# Altium schematic sheet LCD.SchDoc
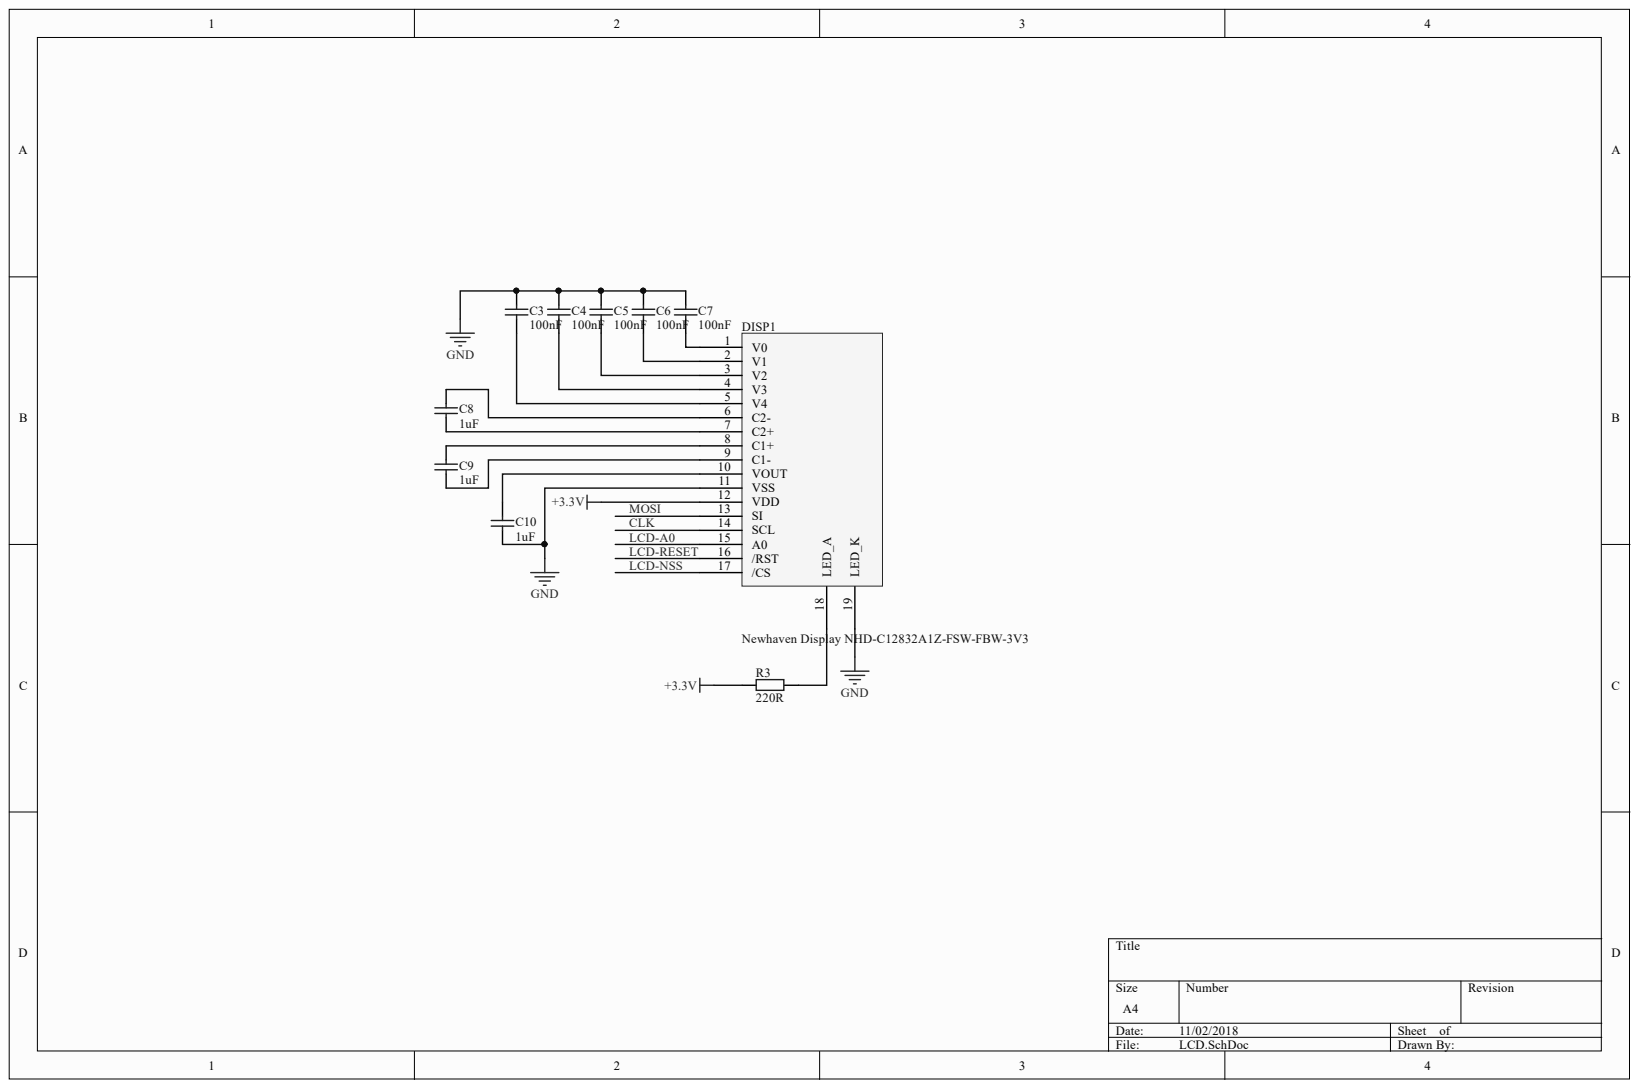
[diagram p0: LCD.SchDoc]

## Parts
C3  100nF  [PCB-14j6yrx10pagyq47cjuv-1]
C4  100nF  [PCB-14j6yrx10pagyq47cjuv-1]
C5  100nF  [PCB-14j6yrx10pagyq47cjuv-1]
C6  100nF  [PCB-14j6yrx10pagyq47cjuv-1]
C7  100nF  [PCB-14j6yrx10pagyq47cjuv-1]
C8  1uF  [CAPC1608X90X35NL15T15]
C9  1uF  [CAPC1608X90X35NL15T15]
C10  1uF  [CAPC1608X90X35NL15T15]
DISP1  Newhaven Display NHD-C12832A1Z-FSW-FBW-3V3  [Metric_Newhaven Display]
R3  220R  [PCB-jh6ykb2j6l1shybbw4je-1]

## Nets
+3.3V: DISP1.12(VDD) R3.1
GND: C3.2 C4.2 C5.2 C6.2 C7.2 C10.1 DISP1.11(VSS) DISP1.19(LED_K)
CLK: DISP1.14(SCL)
LCD-A0: DISP1.15(A0)
LCD-NSS: DISP1.17(/CS)
LCD-RESET: DISP1.16(/RST)
MOSI: DISP1.13(SI)
NetC3_1: C3.1 DISP1.5(V4)
NetC4_1: C4.1 DISP1.4(V3)
NetC5_1: C5.1 DISP1.3(V2)
NetC6_1: C6.1 DISP1.2(V1)
NetC7_1: C7.1 DISP1.1(V0)
NetC8_1: C8.1 DISP1.7(C2+)
NetC8_2: C8.2 DISP1.6(C2-)
NetC9_1: C9.1 DISP1.9(C1-)
NetC9_2: C9.2 DISP1.8(C1+)
NetC10_2: C10.2 DISP1.10(VOUT)
NetDISP1_18: DISP1.18(LED_A) R3.2

## Library data of the parts
C3-C7 = CMP-cab80115e1b0157f-1: 0603 1 uF 10 V ±10 % Tol. X5R Surface Mount Multilayer Ceramic Capacitor; Capacitance Tolerance: ±10%; Lead-Free Status: Lead Free; Voltage Rating (DC): 10.0 V; Case/Package: 0603; Component Kind: Standard; Dielectric Characteristic: X5R; Library Name: CommunityVault; Mounting Style: Surface Mount; OctopartID: cab80115e1b0157f; Octopart URL: http://octopart.com/0603x105k100ct-walsin+technologies-44502638; Packaging: Cut Tape (CT); RoHS: Compliant
C8-C10 = CMP-48b38119c7be9774-1: Capacitor Ceramic, 0.1uf, 50v, X7r, 10%,; Component Kind: Standard; Library Name: CommunityVault; OctopartID: 48b38119c7be9774
DISP1 = CMP-1539121-11: LCD COG GRAPHIC 128X32; Backlight: LED - White; Display Mode: Transflective; Display Type: FSTN - Film Super-Twisted Nematic; Interface: SPI; Viewing Area: 36.00mm W x 12.00mm H; Voltage Backlight: 3 V; Component Kind: Standard; Dot Pixels: 128 x 32; Library Name: CommunityVault; OctopartID: f1ca39de7c5d2e0d; Octopart Url: https://octopart.com/nhd-c12832a1z-fsw-fbw-3v3-newhaven+display-18786545; Voltage Display: 3 V
R3 = CMP-12ccd94cba48cd0b-1: Res Thick Film 0805 220 Ohm 1% 0.33W(1/3W) ±100ppm/C Molded SMD Automotive Paper T/R; Manufacturer Part Number: CRCW0805220RFKEAHP; Case/Package (SI): 2012; Lead-Free Status: Lead Free; Power Rating: 125 mW; Resistance: 220 Ω; Voltage Rating (DC): 150 V; Case/Package: 0805; Component Kind: Standard; Library Name: CommunityVault; Mounting Style: Surface Mount; Number of Pins: 2; OctopartID: 12ccd94cba48cd0b; Octopart URL: http://octopart.com/crcw0805220rfkeahp-vishay-42634722; Packaging: Tape & Reel (TR); REACH SVHC Compliance: No SVHC; Resistance Tolerance: ±1%; RoHS: Compliant
